# Altium schematic sheet Main.SchDoc
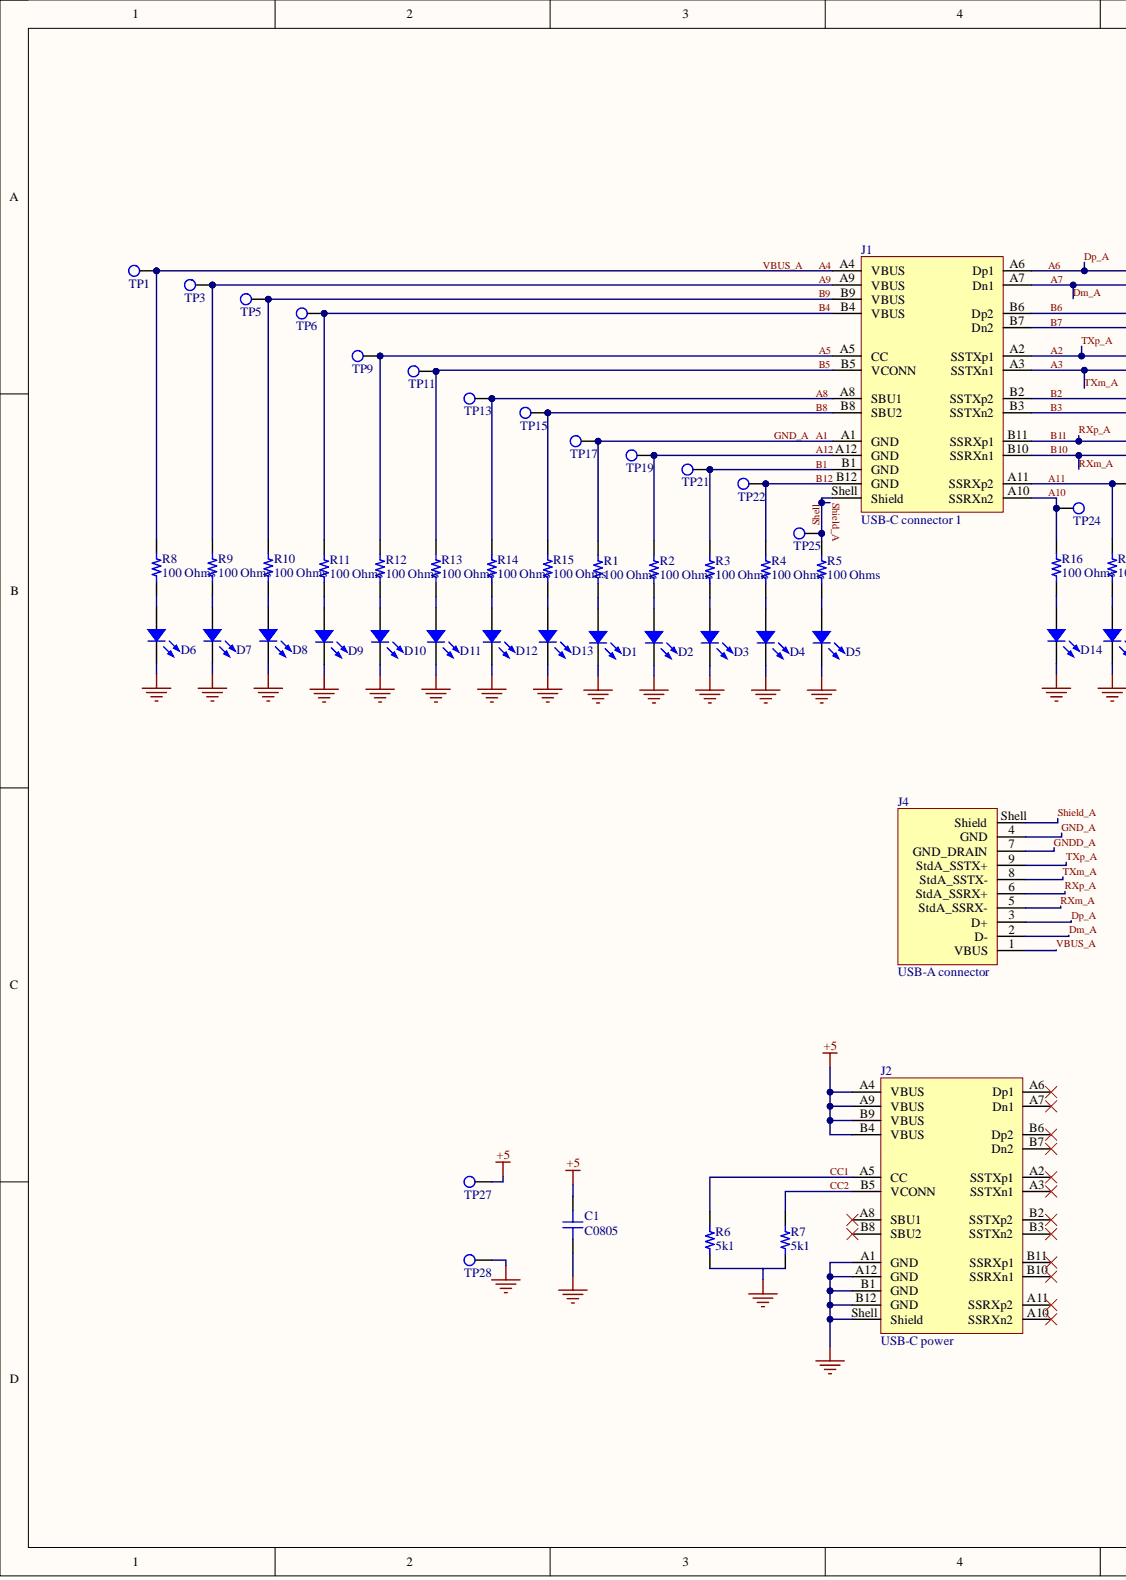
[diagram p0: Main.SchDoc, left]
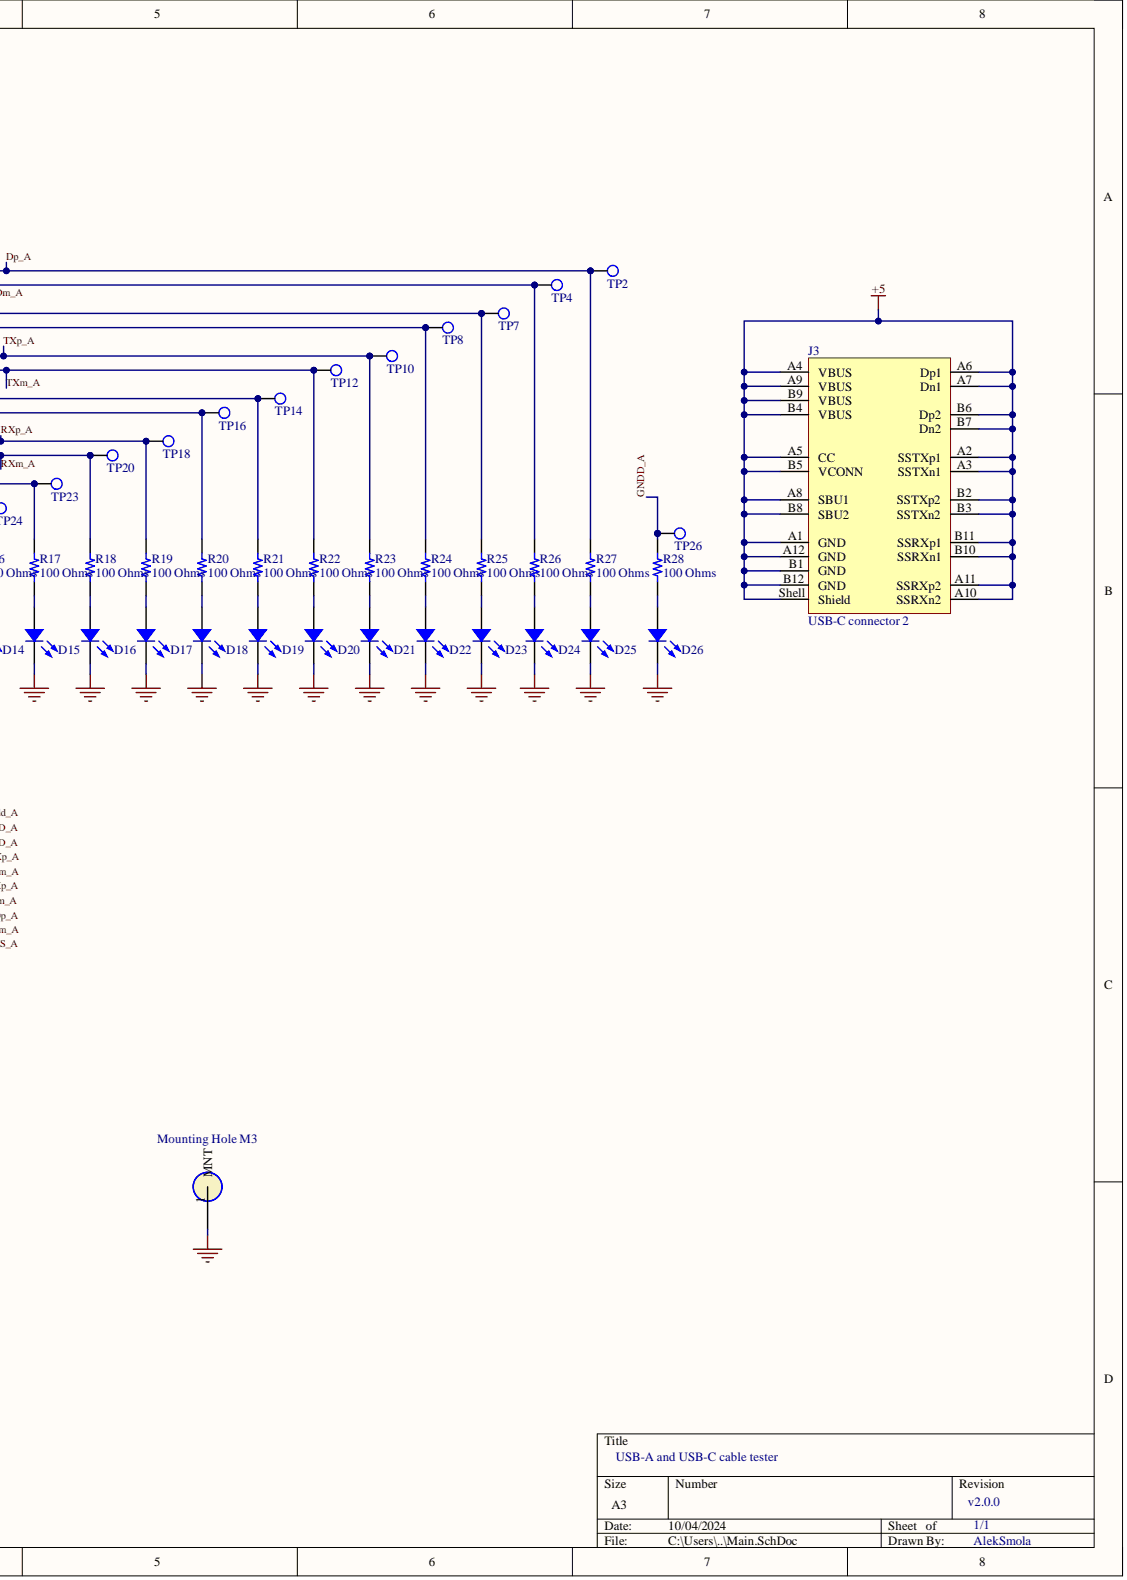
[diagram p1: Main.SchDoc, right]

## Parts
C1  C0805  [CAP_0805]
D1  Yellow  [LED 0805_2012 YELLOW]  (value not drawn)
D2  Yellow  [LED 0805_2012 YELLOW]  (value not drawn)
D3  Yellow  [LED 0805_2012 YELLOW]  (value not drawn)
D4  Yellow  [LED 0805_2012 YELLOW]  (value not drawn)
D5  Yellow  [LED 0805_2012 YELLOW]  (value not drawn)
D6  Yellow  [LED 0805_2012 YELLOW]  (value not drawn)
D7  Yellow  [LED 0805_2012 YELLOW]  (value not drawn)
D8  Yellow  [LED 0805_2012 YELLOW]  (value not drawn)
D9  Yellow  [LED 0805_2012 YELLOW]  (value not drawn)
D10  Yellow  [LED 0805_2012 YELLOW]  (value not drawn)
D11  Yellow  [LED 0805_2012 YELLOW]  (value not drawn)
D12  Yellow  [LED 0805_2012 YELLOW]  (value not drawn)
D13  Yellow  [LED 0805_2012 YELLOW]  (value not drawn)
D14  Yellow  [LED 0805_2012 YELLOW]  (value not drawn)
D15  Yellow  [LED 0805_2012 YELLOW]  (value not drawn)
D16  Yellow  [LED 0805_2012 YELLOW]  (value not drawn)
D17  Yellow  [LED 0805_2012 YELLOW]  (value not drawn)
D18  Yellow  [LED 0805_2012 YELLOW]  (value not drawn)
D19  Yellow  [LED 0805_2012 YELLOW]  (value not drawn)
D20  Yellow  [LED 0805_2012 YELLOW]  (value not drawn)
D21  Yellow  [LED 0805_2012 YELLOW]  (value not drawn)
D22  Yellow  [LED 0805_2012 YELLOW]  (value not drawn)
D23  Yellow  [LED 0805_2012 YELLOW]  (value not drawn)
D24  Yellow  [LED 0805_2012 YELLOW]  (value not drawn)
D25  Yellow  [LED 0805_2012 YELLOW]  (value not drawn)
D26  Yellow  [LED 0805_2012 YELLOW]  (value not drawn)
J1  USB-C connector 1  [usbc_24pin_femal_thruhole]
J2  USB-C power  [usbc_24pin_femal_thruhole]
J3  USB-C connector 2  [usbc_24pin_femal_thruhole]
J4  USB-A connector  [692121030100]
MH1  Mounting Hole M3  [MountingHole_M3]
R1  100 Ohms  [RES 0805_2012]
R2  100 Ohms  [RES 0805_2012]
R3  100 Ohms  [RES 0805_2012]
R4  100 Ohms  [RES 0805_2012]
R5  100 Ohms  [RES 0805_2012]
R6  5k1  [RES 0805_2012]
R7  5k1  [RES 0805_2012]
R8  100 Ohms  [RES 0805_2012]
R9  100 Ohms  [RES 0805_2012]
R10  100 Ohms  [RES 0805_2012]
R11  100 Ohms  [RES 0805_2012]
R12  100 Ohms  [RES 0805_2012]
R13  100 Ohms  [RES 0805_2012]
R14  100 Ohms  [RES 0805_2012]
R15  100 Ohms  [RES 0805_2012]
R16  100 Ohms  [RES 0805_2012]
R17  100 Ohms  [RES 0805_2012]
R18  100 Ohms  [RES 0805_2012]
R19  100 Ohms  [RES 0805_2012]
R20  100 Ohms  [RES 0805_2012]
R21  100 Ohms  [RES 0805_2012]
R22  100 Ohms  [RES 0805_2012]
R23  100 Ohms  [RES 0805_2012]
R24  100 Ohms  [RES 0805_2012]
R25  100 Ohms  [RES 0805_2012]
R26  100 Ohms  [RES 0805_2012]
R27  100 Ohms  [RES 0805_2012]
R28  100 Ohms  [RES 0805_2012]
TP1  TestPoint 1mm  [TestPoint_Altium]  (value not drawn)  (no BOM)
TP2  TestPoint 1mm  [TestPoint_Altium]  (value not drawn)  (no BOM)
TP3  TestPoint 1mm  [TestPoint_Altium]  (value not drawn)  (no BOM)
TP4  TestPoint 1mm  [TestPoint_Altium]  (value not drawn)  (no BOM)
TP5  TestPoint 1mm  [TestPoint_Altium]  (value not drawn)  (no BOM)
TP6  TestPoint 1mm  [TestPoint_Altium]  (value not drawn)  (no BOM)
TP7  TestPoint 1mm  [TestPoint_Altium]  (value not drawn)  (no BOM)
TP8  TestPoint 1mm  [TestPoint_Altium]  (value not drawn)  (no BOM)
TP9  TestPoint 1mm  [TestPoint_Altium]  (value not drawn)  (no BOM)
TP10  TestPoint 1mm  [TestPoint_Altium]  (value not drawn)  (no BOM)
TP11  TestPoint 1mm  [TestPoint_Altium]  (value not drawn)  (no BOM)
TP12  TestPoint 1mm  [TestPoint_Altium]  (value not drawn)  (no BOM)
TP13  TestPoint 1mm  [TestPoint_Altium]  (value not drawn)  (no BOM)
TP14  TestPoint 1mm  [TestPoint_Altium]  (value not drawn)  (no BOM)
TP15  TestPoint 1mm  [TestPoint_Altium]  (value not drawn)  (no BOM)
TP16  TestPoint 1mm  [TestPoint_Altium]  (value not drawn)  (no BOM)
TP17  TestPoint 1mm  [TestPoint_Altium]  (value not drawn)  (no BOM)
TP18  TestPoint 1mm  [TestPoint_Altium]  (value not drawn)  (no BOM)
TP19  TestPoint 1mm  [TestPoint_Altium]  (value not drawn)  (no BOM)
TP20  TestPoint 1mm  [TestPoint_Altium]  (value not drawn)  (no BOM)
TP21  TestPoint 1mm  [TestPoint_Altium]  (value not drawn)  (no BOM)
TP22  TestPoint 1mm  [TestPoint_Altium]  (value not drawn)  (no BOM)
TP23  TestPoint 1mm  [TestPoint_Altium]  (value not drawn)  (no BOM)
TP24  TestPoint 1mm  [TestPoint_Altium]  (value not drawn)  (no BOM)
TP25  TestPoint 1mm  [TestPoint_Altium]  (value not drawn)  (no BOM)
TP26  TestPoint 1mm  [TestPoint_Altium]  (value not drawn)  (no BOM)
TP27  TestPoint 1mm  [TestPoint_Altium]  (value not drawn)  (no BOM)
TP28  TestPoint 1mm  [TestPoint_Altium]  (value not drawn)  (no BOM)

## Nets
+5: C1.2 J2.A4(VBUS) J2.A9(VBUS) J2.B4(VBUS) J2.B9(VBUS) J3.A1(GND) J3.A2(SSTXp1) J3.A3(SSTXn1) J3.A4(VBUS) J3.A5(CC) J3.A6(Dp1) J3.A7(Dn1) J3.A8(SBU1) J3.A9(VBUS) J3.A10(SSRXn2) J3.A11(SSRXp2) J3.A12(GND) J3.B1(GND) J3.B2(SSTXp2) J3.B3(SSTXn2) J3.B4(VBUS) J3.B5(VCONN) J3.B6(Dp2) J3.B7(Dn2) J3.B8(SBU2) J3.B9(VBUS) J3.B10(SSRXn1) J3.B11(SSRXp1) J3.B12(GND) J3.Shell(Shield) TP27.0
GND: C1.1 D1.2 D2.2 D3.2 D4.2 D5.2 D6.2 D7.2 D8.2 D9.2 D10.2 D11.2 D12.2 D13.2 D14.2 D15.2 D16.2 D17.2 D18.2 D19.2 D20.2 D21.2 D22.2 D23.2 D24.2 D25.2 D26.2 J2.A1(GND) J2.A12(GND) J2.B1(GND) J2.B12(GND) J2.Shell(Shield) MH1.1 R6.1 R7.1 TP28.0
A1: J1.A1(GND) J4.4(GND) R1.2 TP17.0
A2: J1.A2(SSTXp1) J4.9(StdA_SSTX+) R23.2 TP10.0
A3: J1.A3(SSTXn1) J4.8(StdA_SSTX-) R22.2 TP12.0
A4: J1.A4(VBUS) J4.1(VBUS) R8.2 TP1.0
A5: J1.A5(CC) R12.2 TP9.0
A6: J1.A6(Dp1) J4.3(D+) R27.2 TP2.0
A7: J1.A7(Dn1) J4.2(D-) R26.2 TP4.0
A8: J1.A8(SBU1) R14.2 TP13.0
A9: J1.A9(VBUS) R9.2 TP3.0
A10: J1.A10(SSRXn2) R16.2 TP24.0
A11: J1.A11(SSRXp2) R17.2 TP23.0
A12: J1.A12(GND) R2.2 TP19.0
B1: J1.B1(GND) R3.2 TP21.0
B2: J1.B2(SSTXp2) R21.2 TP14.0
B3: J1.B3(SSTXn2) R20.2 TP16.0
B4: J1.B4(VBUS) R11.2 TP6.0
B5: J1.B5(VCONN) R13.2 TP11.0
B6: J1.B6(Dp2) R25.2 TP7.0
B7: J1.B7(Dn2) R24.2 TP8.0
B8: J1.B8(SBU2) R15.2 TP15.0
B9: J1.B9(VBUS) R10.2 TP5.0
B10: J1.B10(SSRXn1) J4.5(StdA_SSRX-) R18.2 TP20.0
B11: J1.B11(SSRXp1) J4.6(StdA_SSRX+) R19.2 TP18.0
B12: J1.B12(GND) R4.2 TP22.0
CC1: J2.A5(CC) R6.2
CC2: J2.B5(VCONN) R7.2
GNDD_A: J4.7(GND_DRAIN) R28.2 TP26.0
Shell: J1.Shell(Shield) J4.Shell(Shield) R5.2 TP25.0
NetD1_1: D1.1 R1.1
NetD2_1: D2.1 R2.1
NetD3_1: D3.1 R3.1
NetD4_1: D4.1 R4.1
NetD5_1: D5.1 R5.1
NetD6_1: D6.1 R8.1
NetD7_1: D7.1 R9.1
NetD8_1: D8.1 R10.1
NetD9_1: D9.1 R11.1
NetD10_1: D10.1 R12.1
NetD11_1: D11.1 R13.1
NetD12_1: D12.1 R14.1
NetD13_1: D13.1 R15.1
NetD14_1: D14.1 R16.1
NetD15_1: D15.1 R17.1
NetD16_1: D16.1 R18.1
NetD17_1: D17.1 R19.1
NetD18_1: D18.1 R20.1
NetD19_1: D19.1 R21.1
NetD20_1: D20.1 R22.1
NetD21_1: D21.1 R23.1
NetD22_1: D22.1 R24.1
NetD23_1: D23.1 R25.1
NetD24_1: D24.1 R26.1
NetD25_1: D25.1 R27.1
NetD26_1: D26.1 R28.1

Not connected: J2 A2(SSTXp1) A3(SSTXn1) A6(Dp1) A7(Dn1) A8(SBU1) A10(SSRXn2) A11(SSRXp2) B2(SSTXp2) B3(SSTXn2) B6(Dp2) B7(Dn2) B8(SBU2) B10(SSRXn1) B11(SSRXp1)

## Library data of the parts
C1 = CAP_0805: Capacitor SMD 0805; Component Kind: Standard; Library Name: Schematic-Lib-PhilsLab.SchLib
D1-D26 = SML-LX0805YC-TR: LED YELLOW CLEAR SMD; Manufacturer: Lumex Opto/Components Inc.; Configuration: Standard; Current - Test: 20mA; Database Table Name: LED - Indication; Lens Color: Colorless; Lens Size: 1.40mm x 1.25mm; Lens Style: Rectangle with Flat Top; Millicandela Rating: 9mcd; Viewing Angle: 140°; Voltage - Forward (Vf) (Typ): 2.1V; Wavelength - Peak: 585nm; Color: Yellow; Component Kind: Standard; ComponentLink1Description: Datasheet; ComponentLink1URL: https://www.lumex.com/content/files/ProductAttachment/SML-LX0805YC-TR.pdf; ComponentLink2Description: DigiKey Link; ComponentLink2URL: https://www.digikey.com/en/products/detail/lumex-opto-components-inc/SML-LX0805YC-TR/515791; Device Package: 0805 (2012 Metric); Height (Max): 1.20mm; LastUpdated: 03/06/2024 20:01:27; Lens Transparency: Clear; Library Name: Celestial Altium Library_altium_library.DbLib; Lifecycle Status: Active; Minimum Order: 1; Mounting Type: Surface Mount; Package / Case: 0805 (2012 Metric); Packaging: TapeAndReel; Part Number: SML-LX0805YC-TR; Part Status: Active; PartId: 39; Price: 0.04; Series: -; Size / Dimension: 2.00mm L x 1.25mm W; Supplier 1: DigiKey; Supplier Part Number 1: 67-2192-1-ND; Value: Yellow
J1-J3 = USBC_femal_Conn_24pins_thhole: CMP-1502-03214-3 <- based on some premade connector; NexarPartId: 68112675; Connector Type: USB; Contact Resistance: 30mΩ; Current Rating: 5A; Gender: Receptacle; Insulation Resistance: 1GΩ; Number of Contacts: 24; Pin Length: 1.9mm; USB Standard: USB 3.1; Voltage Rating: 20V; Component Kind: Standard; Contact Material: Gold, Nickel; Height: 3.21mm; Max Operating Temperature: 105°C; Min Operating Temperature: -40°C; Mount: Surface Mount, Through Hole; Number of Pins: 24; Orientation: Horizontal; Packaging: Tape and Reel; RoHS: Compliant; Library Name: Olek_My_Library.IntLib
J4 = CMP-1502-03216-3: NexarPartId: 19667814; Connector Type: USB; Contact Resistance: 50mΩ; Current Rating: 1.8A; Gender: Receptacle; Insulation Resistance: 100MΩ; Number of Contacts: 9; Number of Ports: 1; Operating Supply Voltage: 30V; USB Standard: USB 3.0; Color: Blue; Component Kind: Standard; Contact Material: Bronze, Gold, Nickel; Contact Plating: Gold; Features: Shielded; Flammability Rating: UL94 V-0; Height: 7.87mm; Lead Free: Lead Free; Length: 15.48mm; Library Name: Altium Content Vault; Max Operating Temperature: 85°C; Min Operating Temperature: -20°C; Mount: Horizontal, Through Hole; Number of Pins: 9; Orientation: Horizontal; Packaging: Tape and Reel; REACH SVHC: Unknown; RoHS: Compliant; Termination: Through Hole; Voltage Rating: 30V; Voltage Rating (AC): 30V; Width: 16.48mm
MH1 = MountingHole_M3: Component Kind: Standard; Library Name: Olek_My_Library.IntLib
R1-R5 R8-R28 = RCS0805100RFKEA: RES SMD 100 OHM 1% 1/2W 0805; Manufacturer: Vishay Dale; Database Table Name: Resistors - Surface Mount - 0805; Power (Watts): 0.5W, 1/2W; Temperature Coefficient: ±100ppm/°C; Component Kind: Standard; ComponentLink1Description: Datasheet; ComponentLink1URL: http://www.vishay.com/docs/20065/rcse3.pdf; ComponentLink2Description: DigiKey Link; ComponentLink2URL: https://www.digikey.com/en/products/detail/vishay-dale/RCS0805100RFKEA/5866980; Composition: Thick Film; Device Package: 0805 (2012 Metric); Features: Automotive AEC-Q200, Pulse Withstanding; Height - Seated (Max): 0.020" (0.50mm); LastUpdated: 08/02/2024 21:02:38; Library Name: Celestial Altium Library_altium_library.DbLib; Lifecycle Status: Active; Minimum Order: 1; Number of Terminations: 2; Operating Temperature: -55°C ~ 155°C; Package / Case: 0805 (2012 Metric); Packaging: TapeAndReel; Part Number: RCS0805100RFKEA; Part Status: Active; PartId: 27784; Price: 0.02; Ratings: AEC-Q200; Resistance: 100 Ohms; Series: RCS e3; Size / Dimension: 0.079" L x 0.049" W (2.00mm x 1.25mm); Supplier 1: DigiKey; Supplier Device Package: 0805; Supplier Part Number 1: 541-2834-1-ND; Tolerance: ±1%; Value: 100 Ohms
R6 R7 = RCS0805100RFKEA: RES SMD 100 OHM 1% 1/2W 0805; Manufacturer: Vishay Dale; Database Table Name: Resistors - Surface Mount - 0805; Power (Watts): 0.5W, 1/2W; Resistance: 100 Ohms; Temperature Coefficient: ±100ppm/°C; Component Kind: Standard; ComponentLink1Description: Datasheet; ComponentLink1URL: http://www.vishay.com/docs/20065/rcse3.pdf; ComponentLink2Description: DigiKey Link; ComponentLink2URL: https://www.digikey.com/en/products/detail/vishay-dale/RCS0805100RFKEA/5866980; Composition: Thick Film; Device Package: 0805 (2012 Metric); Features: Automotive AEC-Q200, Pulse Withstanding; Height - Seated (Max): 0.020" (0.50mm); LastUpdated: 08/02/2024 21:02:38; Library Name: Celestial Altium Library_altium_library.DbLib; Lifecycle Status: Active; Minimum Order: 1; Number of Terminations: 2; Operating Temperature: -55°C ~ 155°C; Package / Case: 0805 (2012 Metric); Packaging: TapeAndReel; Part Number: RCS0805100RFKEA; Part Status: Active; PartId: 27784; Price: 0.02; Ratings: AEC-Q200; Series: RCS e3; Size / Dimension: 0.079" L x 0.049" W (2.00mm x 1.25mm); Supplier 1: DigiKey; Supplier Device Package: 0805; Supplier Part Number 1: 541-2834-1-ND; Tolerance: ±1%; Value: 5k1
TP1-TP28 = TestPoint_Altium: Library Name: Olek_My_Library.IntLib
